# Altium schematic sheet Extension_Section.SchDoc
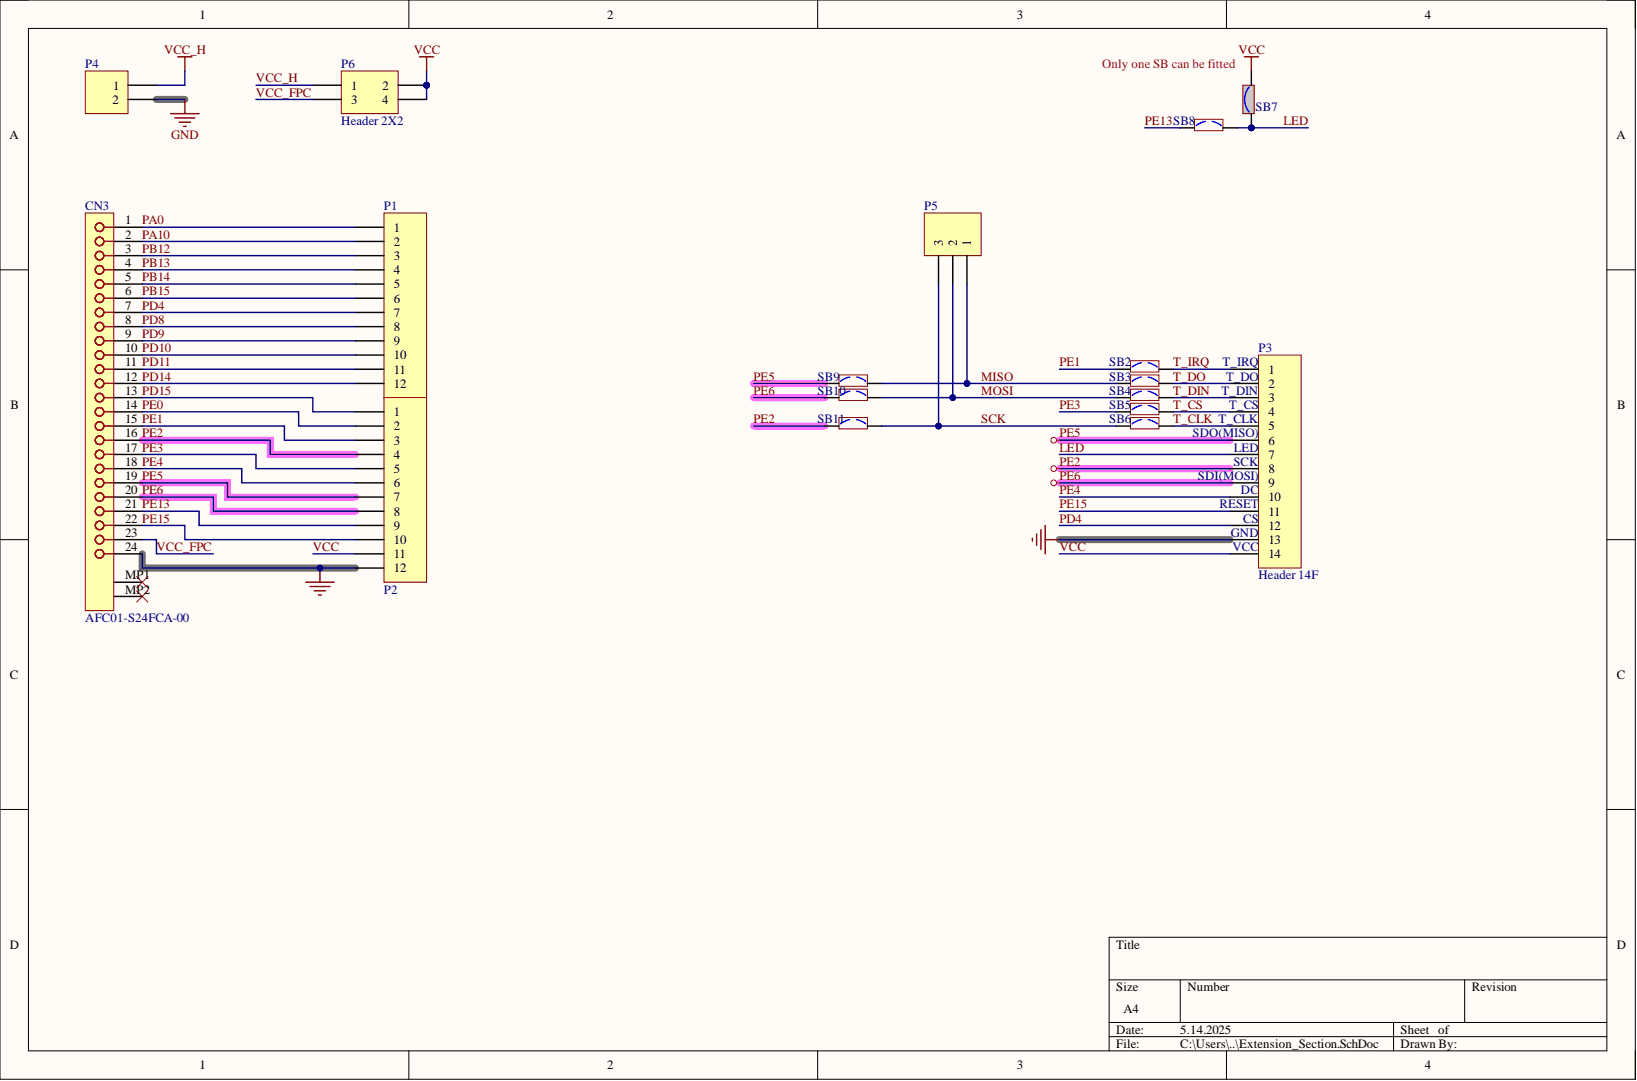
[diagram p0: Extension_Section.SchDoc]

## Parts
CN3  AFC01-S24FCA-00  [AFC01-S24FCA-00]
P1  Header 12  [HDR1X12]  (value not drawn)
P2  Header 12  [HDR1X12]  (value not drawn)
P3  Header 14F  [HDR1X14]
P4  Header 2  [HDR1X2]  (value not drawn)
P5  Header 3  [HDR1X3]  (value not drawn)
P6  Header 2X2  [HDR2X2]
SB2  SB_OFF  [RESC1608X55X25ML10T15]  (value not drawn)
SB3  SB_OFF  [RESC1608X55X25ML10T15]  (value not drawn)
SB4  SB_OFF  [RESC1608X55X25ML10T15]  (value not drawn)
SB5  SB_OFF  [RESC1608X55X25ML10T15]  (value not drawn)
SB6  SB_OFF  [RESC1608X55X25ML10T15]  (value not drawn)
SB7  SB_ON  [RESC1608X55X25ML10T15]  (value not drawn)
SB8  SB_OFF  [RESC1608X55X25ML10T15]  (value not drawn)
SB9  SB_OFF  [RESC1608X55X25ML10T15]  (value not drawn)
SB10  SB_OFF  [RESC1608X55X25ML10T15]  (value not drawn)
SB11  SB_OFF  [RESC1608X55X25ML10T15]  (value not drawn)

## Nets
GND: CN3.24 P2.12 P3.13 P4.2
LED: P3.7 SB7.1 SB8.2
MISO: P5.1 SB3.1 SB9.2
MOSI: P5.2 SB4.1 SB10.2
PA0: CN3.1 P1.1
PA10: CN3.2 P1.2
PB12: CN3.3 P1.3
PB13: CN3.4 P1.4
PB14: CN3.5 P1.5
PB15: CN3.6 P1.6
PD4: CN3.7 P1.7 P3.12
PD8: CN3.8 P1.8
PD9: CN3.9 P1.9
PD10: CN3.10 P1.10
PD11: CN3.11 P1.11
PD14: CN3.12 P1.12
PD15: CN3.13 P2.1
PE0: CN3.14 P2.2
PE1: CN3.15 P2.3 SB2.1
PE2: CN3.16 P2.4 P3.8 SB11.1
PE3: CN3.17 P2.5 SB5.1
PE4: CN3.18 P2.6 P3.10
PE5: CN3.19 P2.7 P3.6 SB9.1
PE6: CN3.20 P2.8 P3.9 SB10.1
PE13: CN3.21 P2.9 SB8.1
PE15: CN3.22 P2.10 P3.11
SCK: P5.3 SB6.1 SB11.2
T_CLK: P3.5 SB6.2
T_CS: P3.4 SB5.2
T_DIN: P3.3 SB4.2
T_DO: P3.2 SB3.2
T_IRQ: P3.1 SB2.2
VCC: P2.11 P3.14 P6.2 P6.4 SB7.2
VCC_FPC: CN3.23 P6.3
VCC_H: P4.1 P6.1

Not connected: CN3 MP1 MP2

## Library data of the parts
CN3 = CMP-002-00365-1: Flip type 24P Bottom Contact Horizontal attachment 0.5mm SMD,P=0.5mm,Surface Mount，Right Angle FFC, FPC (Flat Flexible) Connector Assemblies ROHS; Connector Type: CARD EDGE CONNECTOR; Component Kind: Standard; Max Operating Temperature: 85°C; Min Operating Temperature: -20°C; Mounting Technology: Board; Pins: 24; RoHS Compliant: Yes; Library Name: Simon Mayr
P1 P2 = CMP-002-00241-1: Header, 12-Pin; Component Kind: Standard; Design Item ID: Header 12; LatestRevisionDate: 17-Jul-2002; LatestRevisionNote: Re-released for DXP Platform.; Source: Miscellaneous Connectors.SchLib; Type: Connector; Library Name: Simon Mayr; Publisher: Altium Limited
P3 = CMP-002-00366-2: Header, 14-Pin, Female; Component Kind: Standard; Design Item ID: Header 14; LatestRevisionDate: 17-Jul-2002; LatestRevisionNote: Re-released for DXP Platform.; Source: Miscellaneous Connectors.SchLib; Type: Connector; Library Name: Simon Mayr; Publisher: Altium Limited
P4 = CMP-002-00207-1: Header, 2-Pin; Component Kind: Standard; Design Item ID: Header 2; LatestRevisionDate: 17-Jul-2002; LatestRevisionNote: Re-released for DXP Platform.; Source: Miscellaneous Connectors.SchLib; Type: Connector; Library Name: Simon Mayr; Publisher: Altium Limited
P5 = CMP-002-00208-1: Header, 3-Pin; Component Kind: Standard; Design Item ID: Header 3; LatestRevisionDate: 17-Jul-2002; LatestRevisionNote: Re-released for DXP Platform.; Source: Miscellaneous Connectors.SchLib; Type: Connector; Library Name: Simon Mayr; Publisher: Altium Limited
P6 = CMP-002-00276-2: Header, 2-Pin, Dual row; Component Kind: Standard; Design Item ID: Header 2X2; LatestRevisionDate: 17-Jul-2002; LatestRevisionNote: Re-released for DXP Platform.; Source: Miscellaneous Connectors.SchLib; Type: Connector; Library Name: Simon Mayr; Publisher: Altium Limited
SB2-SB6 SB8-SB11 = CMP-009-00179-7: Solder Bridge OFF; Package Width: 0.8mm; Power: 100mW; Rated Temperature: 70°C; Value: 0; Case/Package: 0603; Component Kind: Standard; Construction: Chip; JESD-609 Code: e3; Max Operating Temperature: 155°C; Min Operating Temperature: -55°C; Mounting Technology: Surface Mount; Package Shape: Rectangular; Package Style: SMTMeter; Packaging: Tape and Reel; Pins: 2; Reach SVHC Compliant: Yes; Resistor Type: FIXED RESISTOR; RoHS Compliant: Yes; Technology: METAL GLAZE/THICK FILM; Terminal Finish: Nickel; Termination: Wraparound; Library Name: Simon Mayr
SB7 = CMP-00003-3: Solder Bridge ON; Package Width: 0.8mm; Power: 100mW; Rated Temperature: 70°C; Value: 0; Case/Package: 0603; Component Kind: Standard; Construction: Chip; JESD-609 Code: e3; Max Operating Temperature: 155°C; Min Operating Temperature: -55°C; Mounting Technology: Surface Mount; Package Shape: Rectangular; Package Style: SMTMeter; Packaging: Tape and Reel; Pins: 2; Reach SVHC Compliant: Yes; Resistor Type: FIXED RESISTOR; RoHS Compliant: Yes; Technology: METAL GLAZE/THICK FILM; Terminal Finish: Nickel; Termination: Wraparound; Library Name: Simon Mayr
